# Altium schematic sheet W5500-io_V100_Ref.SchDoc
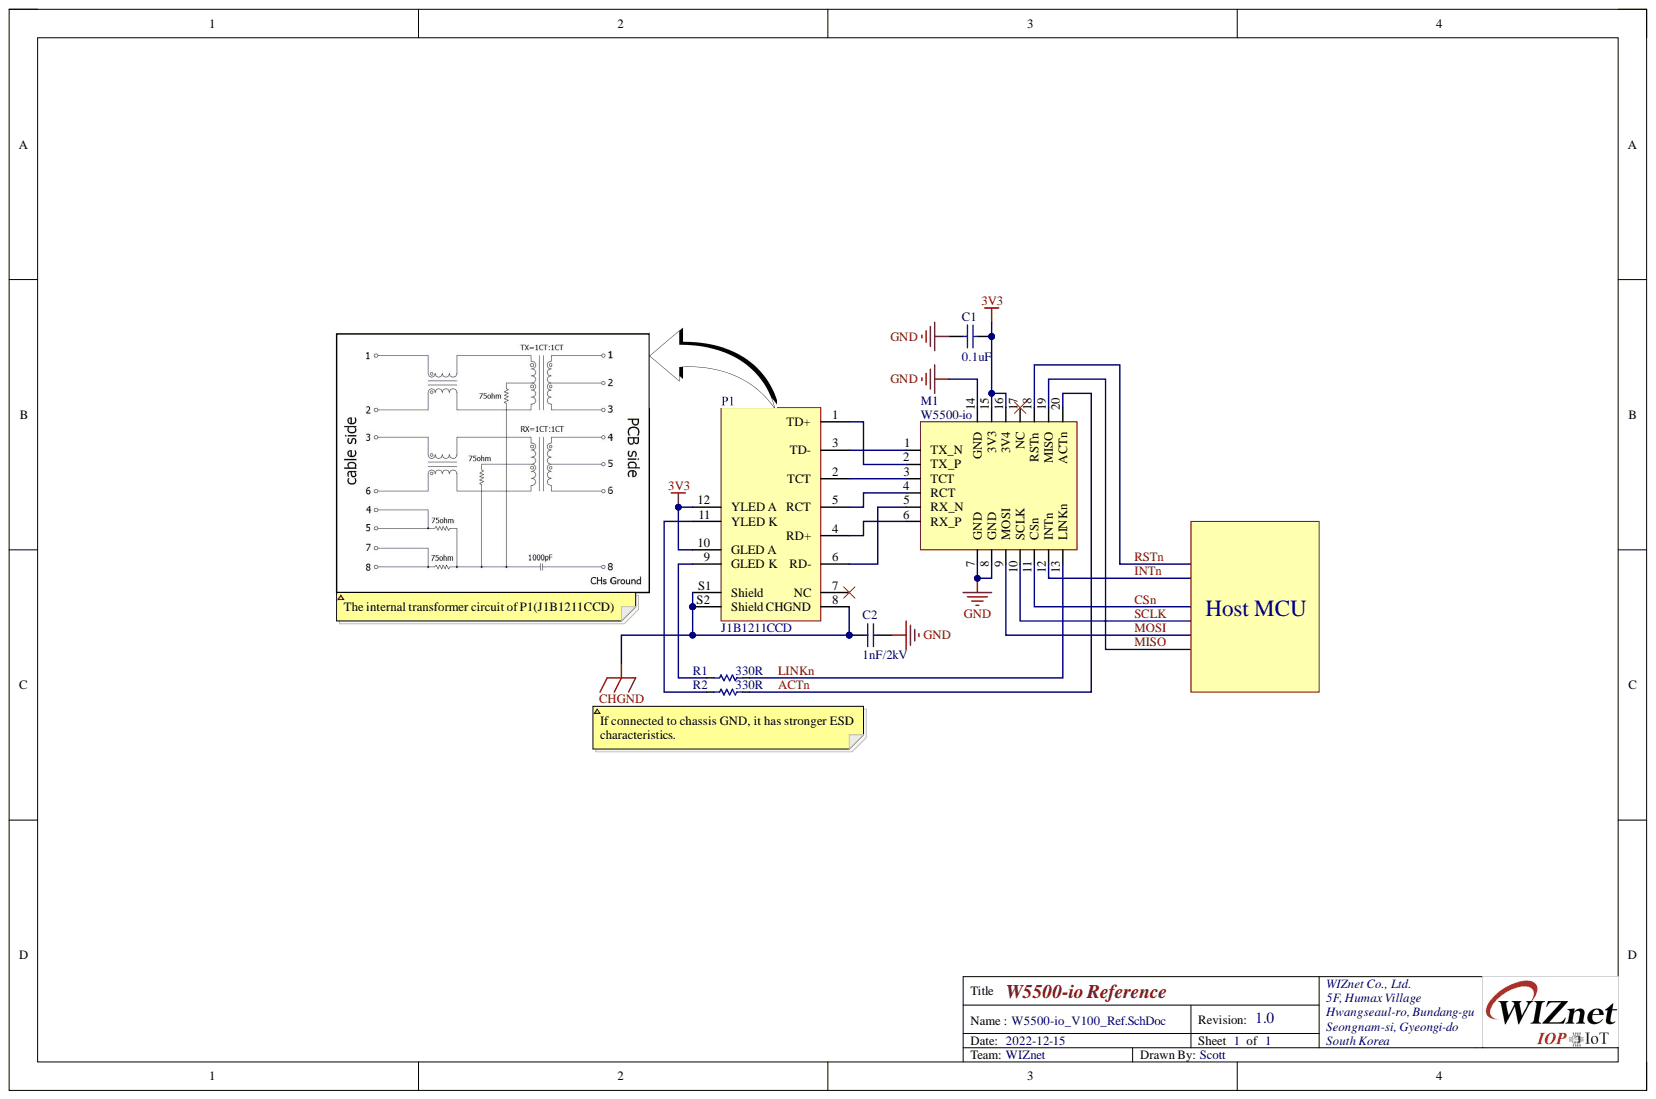
[diagram p0: W5500-io_V100_Ref.SchDoc]

## Parts
C1  0.1uF  [CAP-0603]
C2  1nF/2kV  [CAP-1808]
M1  W5500-io
P1  J1B1211CCD  [RJ45-J1B1211CCD]
R1  330R  [RES-0603]
R2  330R  [RES-0603]

## Nets
3V3: C1.2 M1.15(3V3) M1.16(3V4) P1.10(GLED_A) P1.12
CHGND: C2.1 P1.8(CHGND) P1.S1(Shield) P1.S2(Shield)
GND: C1.1 C2.2 M1.7(GND) M1.8(GND) M1.14(GND)
ACTn: M1.20(ACTn) R2.2
CSn: M1.11(CSn)
INTn: M1.12(INTn)
LINKn: M1.13(LINKn) R1.2
MISO: M1.19(MISO)
MOSI: M1.9(MOSI)
RSTn: M1.18(RSTn)
SCLK: M1.10(SCLK)
NetM1_1: M1.1(TX_N) P1.3(TD-)
NetM1_2: M1.2(TX_P) P1.1(TD+)
NetM1_3: M1.3(TCT) P1.2(TCT)
NetM1_4: M1.4(RCT) P1.5(RCT)
NetM1_5: M1.5(RX_N) P1.6(RD-)
NetM1_6: M1.6(RX_P) P1.4(RD+)
NetP1_9: P1.9 R1.1
NetP1_11: P1.11(YLED_K) R2.1

Not connected: M1 17(NC); P1 7(NC)

## Library data of the parts
C1 = CAP-0603: Ceramic CAP 0603(1608); Component Kind: Standard; Library Name: Scott_lib.IntLib
C2 = CAP-1808: Ceramic CAP 1808(4520); Component Kind: Standard; Library Name: Scott_lib.IntLib
R1 R2 = RES-0603: Component Kind: Standard; Description: RES-0603; Library Name: Scott_lib.IntLib
M1 = W5500-io: Component Kind: Standard; Library Name: Scott_lib.IntLib
P1 = J1B1211CCD: Component Kind: Standard; Description: J1B1211CCD; Library Name: Scott_lib.IntLib
